# Altium schematic sheet USART.SchDoc
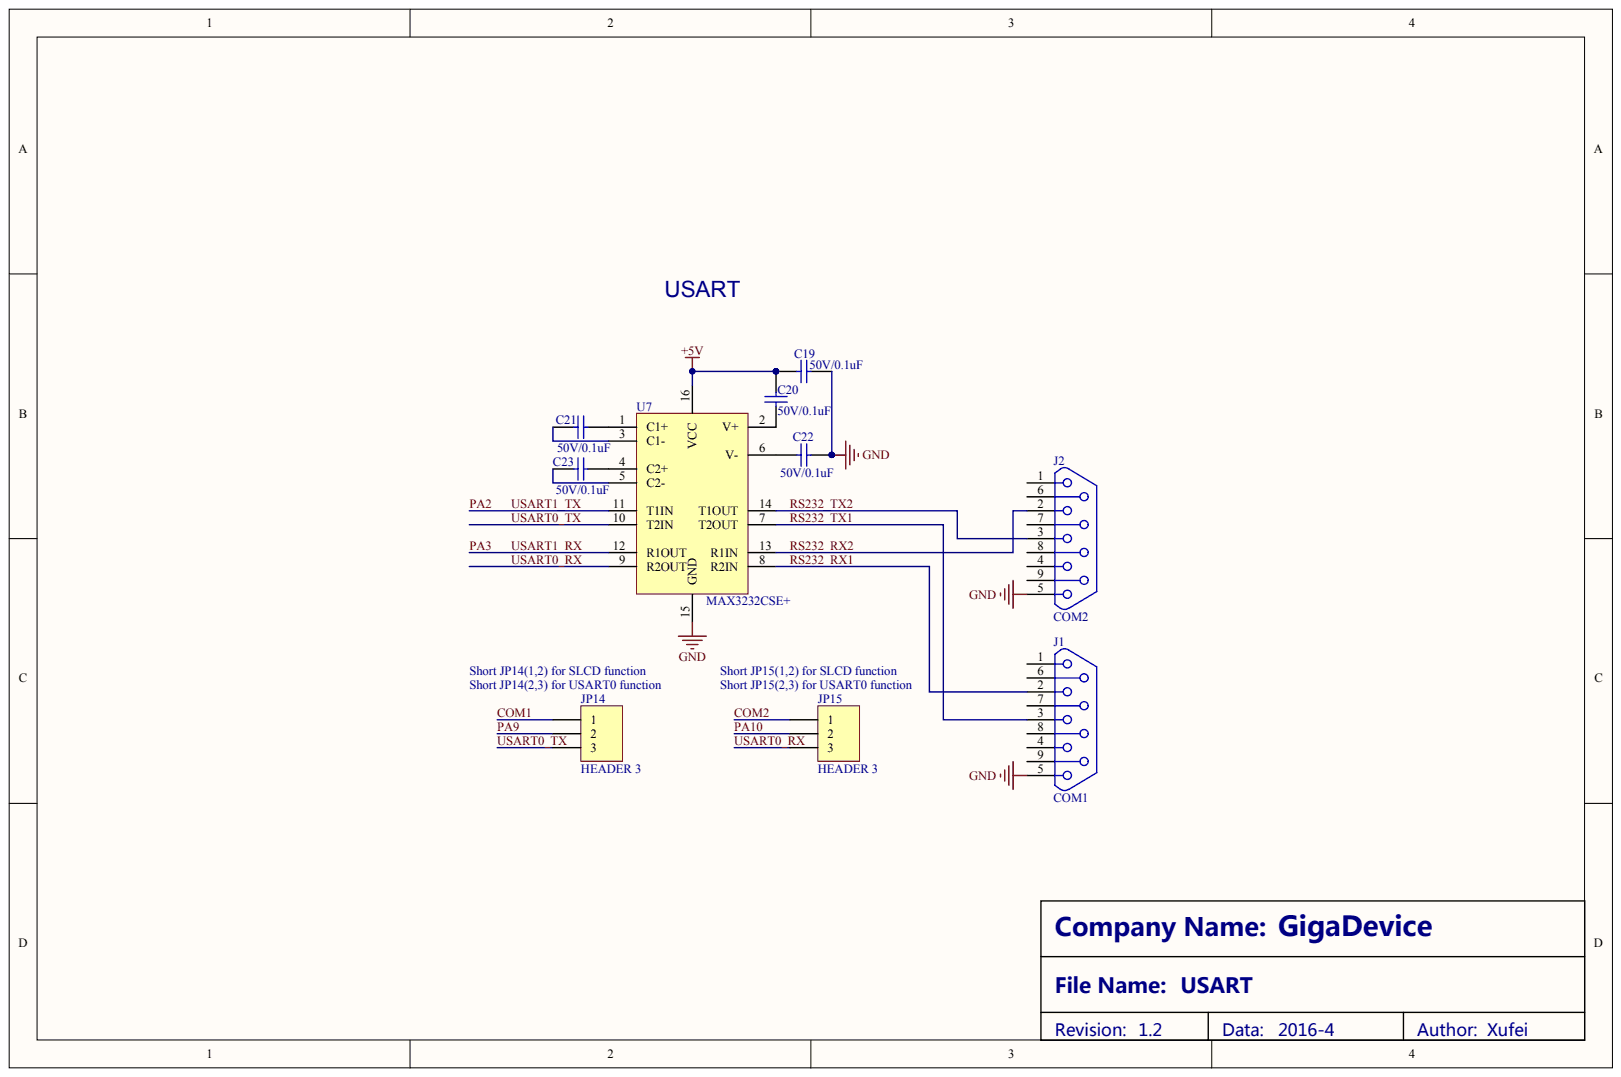
[diagram p0: USART.SchDoc]

## Parts
C19  50V/0.1uF
C20  50V/0.1uF
C21  50V/0.1uF
C22  50V/0.1uF
C23  50V/0.1uF
J1  COM1
J2  COM2
JP14  HEADER 3
JP15  HEADER 3
U7  MAX3232CSE+

## Nets
+5V: C19.1 C20.2 U7.16(VCC)
GND: C19.2 C22.2 J1.5 J2.5 U7.15(GND)
COM1: JP14.1
COM2: JP15.1
PA2: U7.11(T1IN)
PA3: U7.12(R1OUT)
PA9: JP14.2
PA10: JP15.2
RS232_RX1: J1.2 U7.8(R2IN)
RS232_RX2: J2.2 U7.13(R1IN)
RS232_TX1: J1.3 U7.7(T2OUT)
RS232_TX2: J2.3 U7.14(T1OUT)
USART0_RX: JP15.3 U7.9(R2OUT)
USART0_TX: JP14.3 U7.10(T2IN)
NetC20_1: C20.1 U7.2(V+)
NetC21_1: C21.1 U7.1(C1+)
NetC21_2: C21.2 U7.3(C1-)
NetC22_1: C22.1 U7.6(V-)
NetC23_1: C23.1 U7.4(C2+)
NetC23_2: C23.2 U7.5(C2-)

Not connected: J1 1 4 6 7 8 9; J2 1 4 6 7 8 9
